# Altium schematic sheet G37Hat.SchDoc
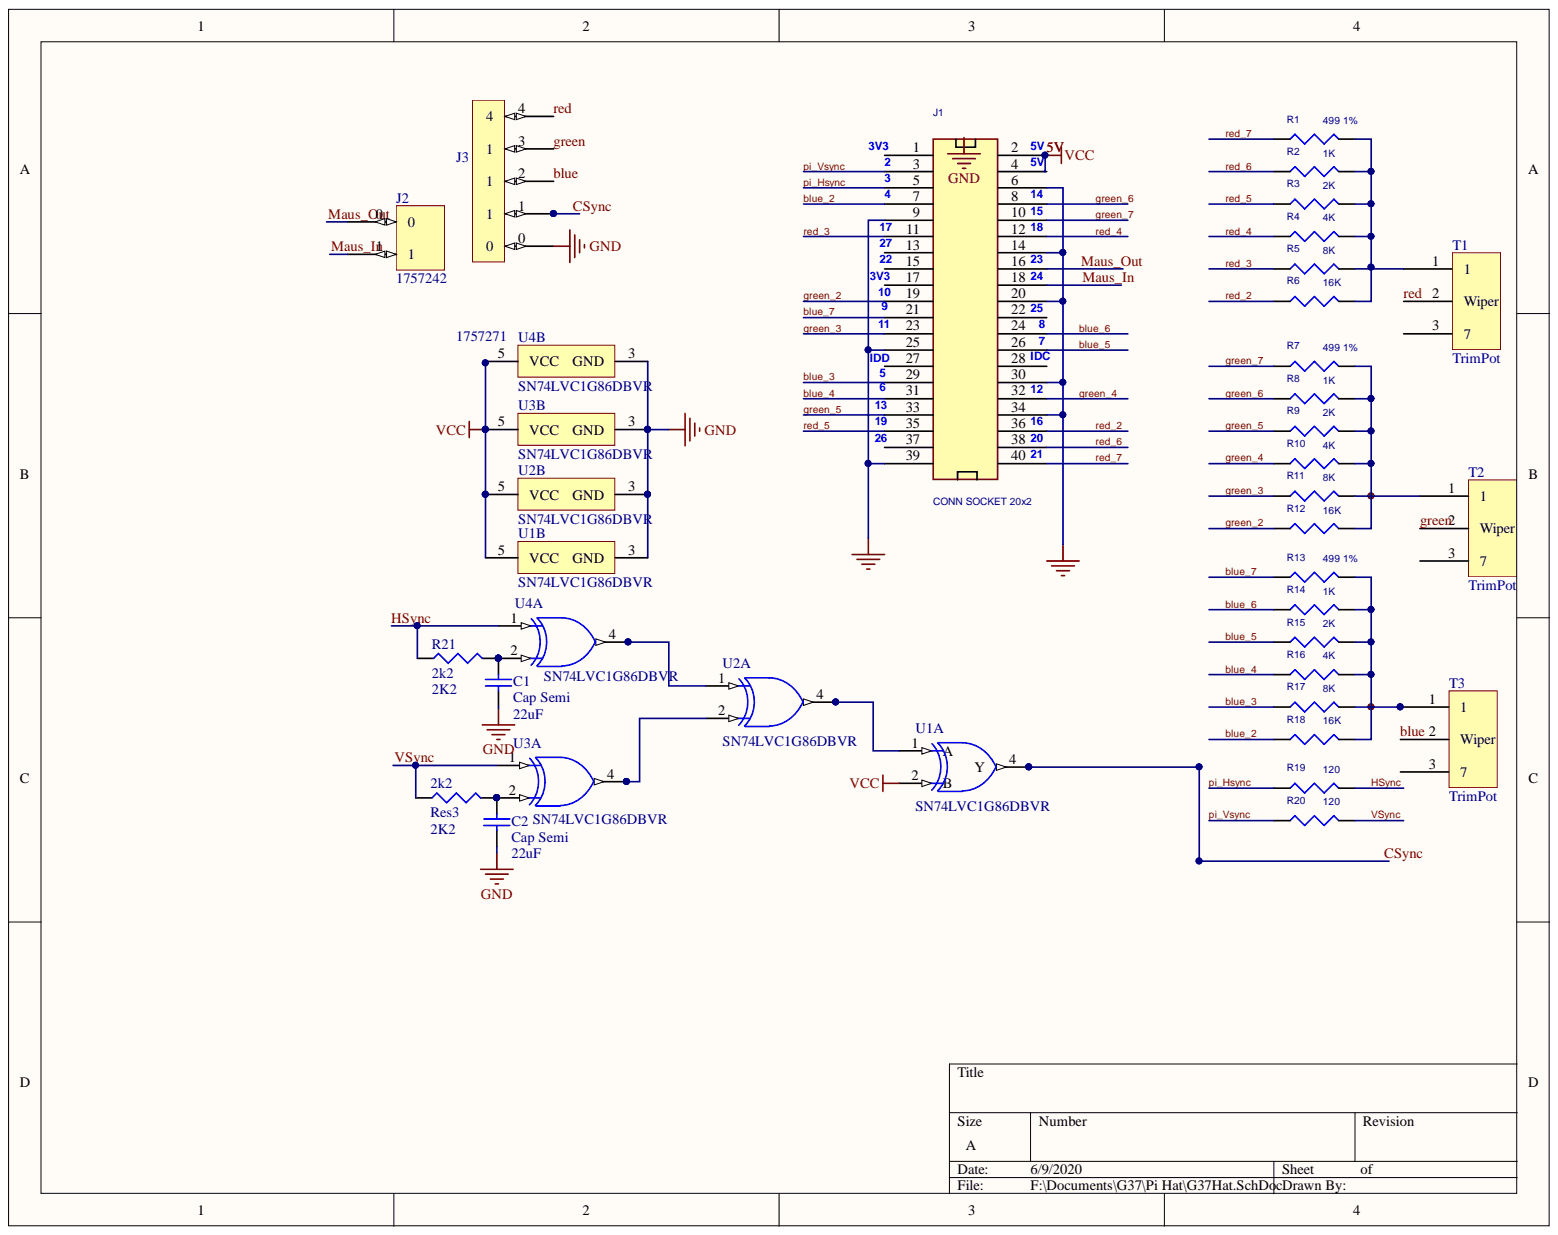
[diagram p0: G37Hat.SchDoc]

## Parts
2k2  Res3  value 2K2  [1608[0603]]
C1  Cap Semi  value 22uF  [1608[0603]]
C2  Cap Semi  value 22uF  [1608[0603]]
J1  CONN SOCKET 20x2  [HDR2X20]
J2  1757242  [1757242]
J3  1757271  [1757271]
R1  499 1%  [1608[0603]]
R2  1K  [1608[0603]]
R3  2K  [1608[0603]]
R4  4K  [1608[0603]]
R5  8K  [1608[0603]]
R6  16K  [1608[0603]]
R7  499 1%  [1608[0603]]
R8  1K  [1608[0603]]
R9  2K  [1608[0603]]
R10  4K  [1608[0603]]
R11  8K  [1608[0603]]
R12  16K  [1608[0603]]
R13  499 1%  [1608[0603]]
R14  1K  [1608[0603]]
R15  2K  [1608[0603]]
R16  4K  [1608[0603]]
R17  8K  [1608[0603]]
R18  16K  [1608[0603]]
R19  120  [1608[0603]]
R20  120  [1608[0603]]
R21  2k2  [1608[0603]]
T1  TrimPot  [3Wire_Trimpot]
T2  TrimPot  [3Wire_Trimpot]
T3  TrimPot  [3Wire_Trimpot]
U1  SN74LVC1G86DBVR  [DBV0005A_N]  (parts A,B)
U2  SN74LVC1G86DBVR  [DBV0005A_N]  (parts A,B)
U3  SN74LVC1G86DBVR  [DBV0005A_N]  (parts A,B)
U4  SN74LVC1G86DBVR  [DBV0005A_N]  (parts A,B)

## Nets
GND: C1.1 C2.1 J1.6 J1.9 J1.14 J1.20 J1.25 J1.30 J1.34 J1.39 J3.0 U1.3(GND) U2.3(GND) U3.3(GND) U4.3(GND)
5V: J1.2 U1.2(B) U1.5(VCC) U2.5(VCC) U3.5(VCC) U4.5(VCC)
blue: J3.2 T3.2
blue_2: J1.7 R18.1
blue_3: J1.29 R17.1
blue_4: J1.31 R16.1
blue_5: J1.26 R15.1
blue_6: J1.24 R14.1
blue_7: J1.21 R13.1
CSync: J3.1 U1.4(Y)
green: J3.3 T2.2
green_2: J1.19 R12.1
green_3: J1.23 R11.1
green_4: J1.32 R10.1
green_5: J1.33 R9.1
green_6: J1.8 R8.1
green_7: J1.10 R7.1
HSync: R19.2 R21.1 U4.1
Maus_In: J1.18 J2.1
Maus_Out: J1.16 J2.0
pi_Hsync: J1.5 R19.1
pi_Vsync: J1.3 R20.1
red: J3.4 T1.2
red_2: J1.36 R6.1
red_3: J1.11 R5.1
red_4: J1.12 R4.1
red_5: J1.35 R3.1
red_6: J1.38 R2.1
red_7: J1.40 R1.1
VSync: 2k2.1 R20.2 U3.1
Net2k2_2: 2k2.2 C2.2 U3.2
NetC1_2: C1.2 R21.2 U4.2
NetR1_2: R1.2 R2.2 R3.2 R4.2 R5.2 R6.2 T1.1
NetR7_2: R7.2 R8.2 R9.2 R10.2 R11.2 R12.2 T2.1
NetR13_2: R13.2 R14.2 R15.2 R16.2 R17.2 R18.2 T3.1
NetU1_1: U1.1(A) U2.4
NetU2_1: U2.1 U4.4
NetU2_2: U2.2 U3.4

Not connected: J1 1 4 13 15 17 22 27 28 37; T1 3; T2 3; T3 3

## Library data of the parts
2k2 R21 = Res3: Resistor; Component Kind: Standard; LatestRevisionDate: 29-May-2009; LatestRevisionNote: IPC-7351 Footprint Added.; Library Name: Miscellaneous Devices.IntLib; PackageDescription: Chip Resistor; PackageReference: J1-0603; Published: 8-Jun-2000; Signal Integrity: Res; Simulation: RESISTOR; Publisher: Altium Limited
  R21: Value: 2K2
C1 C2 = Cap Semi: Capacitor (Semiconductor SIM Model); Component Kind: Standard; LatestRevisionDate: 29-May-2009; LatestRevisionNote: IPC-7351 Footprint Added.; Library Name: Miscellaneous Devices.IntLib; PackageDescription: Chip Capacitor; PackageReference: C1206; Published: 8-Jun-2000; Signal Integrity: Cap Semi; Simulation: CAP; Publisher: Altium Limited
J3 = 1757271: Phoenix Connect; Component Kind: Standard; Library Name: Integrated_Library1.IntLib
R1-R20 = R: Component Kind: Standard
U1-U4 = SN74LVC1G86DBVR: Single 2-Input Exclusive-OR Gate, DBV0005A, LARGE T&R; Manufacturer: Texas Instruments; 3-State Output: No; Bits(#): 1; F @ Nom Voltage(Max)(Mhz): 150; Gate Type: XOR; ICC @ Nom Voltage(Max)(mA): 0.01; Input Type: CMOS/TTL; Logic: True; Output Drive (IOL/IOH)(Max)(mA): 32/-32; Output Type: CMOS; Rating: Catalog; Sub-Family: XOR Gate; Technology Family: LVC; tpd @ Nom Voltage(Max)(ns): 9.9,5.5,5,4; VCC(Max)(V): 5.5; VCC(Min)(V): 1.65; Voltage(Nom)(V): 1.8,2.5,3.3,5; Component Kind: Standard; ComponentLink1Description: Manufacturer URL; ComponentLink1URL: http://www.ti.com/; ComponentLink2Description: Datasheet; ComponentLink2URL: http://www.ti.com/lit/ds/symlink/sn74lvc1g86.pdf; DatasheetVersion: SCES222O; Generic Part Number: SN74LVC1G86; Library Name: TI_Logic_Little_Logic.IntLib; Operating Temperature Range(C): -40 to 125,-40 to 85; PackageReference: DBV0005A; PartNumber: SN74LVC1G86DBVR; Schmitt Trigger: No; Supplier 1: Mouser; Supplier 2: Digi-Key; Supplier 3: Digi-Key; Supplier 4: Digi-Key; Supplier Part Number 1: 595-SN74LVC1G86DBVR; Supplier Part Number 2: 296-9853-2-ND; Supplier Part Number 3: 296-9853-1-ND; Supplier Part Number 4: 296-9853-6-ND
J1 = CONN SOCKET 20x2: Component Kind: Standard
J2 = 1757242: Component Kind: Standard; Library Name: Integrated_Library1.IntLib
T1-T3 = TrimPot: Component Kind: Standard; Library Name: Integrated_Library1.IntLib
